# Altium schematic sheet 3_Sensing_V.SchDoc
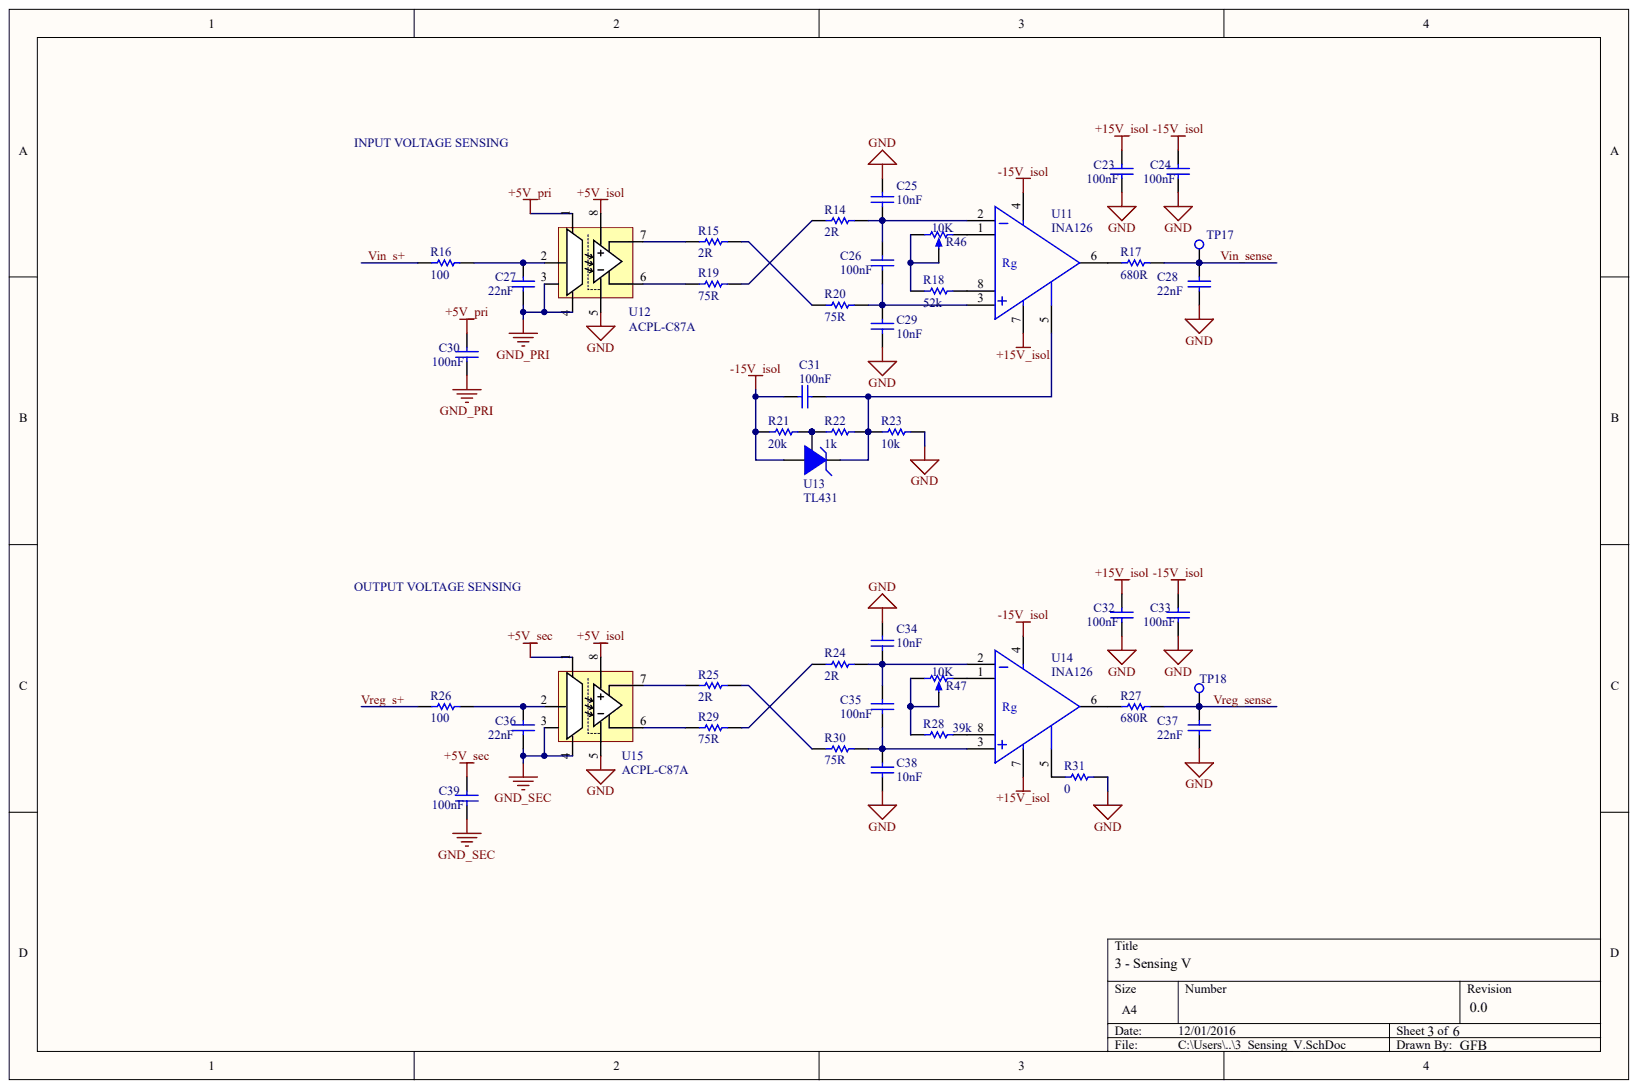
[diagram p0: 3_Sensing_V.SchDoc]

## Parts
C23  value 100nF  (hidden comment: Cap)
C24  value 100nF  (hidden comment: Cap)
C25  value 10nF  (hidden comment: Cap)
C26  value 100nF  (hidden comment: Cap)
C27  value 22nF  (hidden comment: Cap)
C28  value 22nF  (hidden comment: Cap)
C29  value 10nF  (hidden comment: Cap)
C30  value 100nF  (hidden comment: Cap)
C31  value 100nF  (hidden comment: Cap)
C32  value 100nF  (hidden comment: Cap)
C33  value 100nF  (hidden comment: Cap)
C34  value 10nF  (hidden comment: Cap)
C35  value 100nF  (hidden comment: Cap)
C36  value 22nF  (hidden comment: Cap)
C37  value 22nF  (hidden comment: Cap)
C38  value 10nF  (hidden comment: Cap)
C39  value 100nF  (hidden comment: Cap)
R14  value 2R  (hidden comment: Res1)
R15  value 2R  (hidden comment: Res1)
R16  value 100  (hidden comment: Res1)
R17  value 680R  (hidden comment: Res1)
R18  value 52k  (hidden comment: Res1)
R19  value 75R  (hidden comment: Res1)
R20  value 75R  (hidden comment: Res1)
R21  value 20k  (hidden comment: Res1)
R22  value 1k  (hidden comment: Res1)
R23  value 10k  (hidden comment: Res1)
R24  value 2R  (hidden comment: Res1)
R25  value 2R  (hidden comment: Res1)
R26  value 100  (hidden comment: Res1)
R27  value 680R  (hidden comment: Res1)
R28  value 39k  (hidden comment: Res1)
R29  value 75R  (hidden comment: Res1)
R30  value 75R  (hidden comment: Res1)
R31  value 0  (hidden comment: Res1)
R46  value 10K
R47  value 10K
TP17  TestPoint  (value not drawn)
TP18  TestPoint  (value not drawn)
U11  INA126
U12  ACPL-C87A
U13  TL431
U14  INA126
U15  ACPL-C87A

## Nets
+5V_ISOL: U12.8 U15.8
+5V_PRI: C30.2 U12.1
+5V_SEC: C39.2 U15.1
+15V_ISOL: C23.2 C32.2 U11.7 U14.7
-15V_ISOL: C24.2 C31.2 C33.2 R21.1 U11.4 U13.2 U14.4
GND: C23.1 C24.1 C25.2 C28.1 C29.1 C32.1 C33.1 C34.2 C37.1 C38.1 R23.2 R31.1 U12.5 U15.5
GND_PRI: C27.1 C30.1 U12.3 U12.4
GND_SEC: C36.1 C39.1 U15.3 U15.4
VIN_S+: R16.2
VIN_SENSE: C28.2 R17.1 TP17.1
VREG_S+: R26.2
VREG_SENSE: C37.2 R27.1 TP18.1
NetC25_1: C25.1 C26.2 R14.1 U11.2
NetC26_1: C26.1 C29.2 R20.1 U11.3
NetC27_2: C27.2 R16.1 U12.2
NetC31_1: C31.1 R22.2 R23.1 U11.5 U13.3
NetC34_1: C34.1 C35.2 R24.1 U14.2
NetC35_1: C35.1 C38.2 R30.1 U14.3
NetC36_2: C36.2 R26.1 U15.2
NetR14_2: R14.2 R19.1
NetR15_1: R15.1 R20.2
NetR15_2: R15.2 U12.7
NetR17_2: R17.2 U11.6
NetR18_1: R18.1 R46.1 R46.3
NetR18_2: R18.2 U11.8
NetR19_2: R19.2 U12.6
NetR21_2: R21.2 R22.1 U13.1
NetR24_2: R24.2 R29.1
NetR25_1: R25.1 R30.2
NetR25_2: R25.2 U15.7
NetR27_2: R27.2 U14.6
NetR28_1: R28.1 R47.1 R47.3
NetR28_2: R28.2 U14.8
NetR29_2: R29.2 U15.6
NetR31_2: R31.2 U14.5
NetR46_2: R46.2 U11.1
NetR47_2: R47.2 U14.1

## Library data of the parts
R14-R31 = (no symbol name): Power: 5W; Published: 8-Jun-2000; LatestRevisionDate: 17-Jul-2002; LatestRevisionNote: Re-released for DXP Platform.; PackageReference: AXIAL-0.3; PackageDescription: Axial Device, Thru-Hole; 2 Leads; 0.3 in Pin Spacing; Publisher: Altium Limited
  R14-R23: ClassName: Vin_sensing
  R24-R31: ClassName: Vout_sensing
R46 R47 = (no symbol name): Sim Note: Enter the Resistance value and the set point into parameter fields.; Set Position: 0.5; Published: 8-Jun-2000; LatestRevisionDate: 17-Jul-2002; LatestRevisionNote: Re-released for DXP Platform.; PackageReference: VR5; PackageDescription: Variable Resistor, Thru-Hole; 3 Leads; Publisher: Altium Limited
TP17 TP18 = (no symbol name): RS: 100-107
  TP17: ClassName: Vin_sensing
  TP18: ClassName: Vout_sensing
U11 U14 = (no symbol name): Manufacturer: Texas Instruments; Manufacturer Part Number: INA126EA/250; Amplifier Type: Instrumentation; Number of Circuits: 1; Slew Rate: 0.4 V/µs; -3db Bandwidth: 200kHz; Current - Input Bias: 10nA; Voltage - Input Offset: 150µV; Current - Supply: 175µA; Current - Output / Channel: 10mA; Voltage - Supply, Single/Dual (±): 2.7 V ~ 36 V, ±1.35 V ~ 18 V; Supplier 1: Digi-Key; RoHS: RoHS Compliant; Category: Integrated Circuits (ICs); Stock 1: 1250; Pricing 1: 250=2.14448, 500=1.92422 (EUR); Packaging: Tape & Reel (TR); Output Type: -; Gain Bandwidth Product: -; Operating Temperature: -40°C ~ 85°C; Mounting Type: Surface Mount; Package / Case: 8-TSSOP, 8-MSOP (0.118", 3.00mm Width); Supplier Device Package: 8-VSSOP; ComponentLink1URL: http://www.ti.com/lit/ds/symlink/ina126.pdf; ComponentLink1Description: http://www.ti.com/lit/ds/symlink/ina126.pdf; Supplier Part Number 1: INA126EATR-ND; HelpURL: C:\Users\Gonzalo\GoogleDrive\UC3M\Flyback_board\3_datasheets\opamp_ina126.pdf
  U11: ClassName: Vin_sensing
  U14: ClassName: Vout_sensing
C23-C39 U12 U13 U15 = (no symbol name)
  C23-C31: Published: 8-Jun-2000; LatestRevisionDate: 17-Jul-2002; LatestRevisionNote: Re-released for DXP Platform.; PackageReference: RAD-0.3; PackageDescription: Radial Cap, Thru-Hole; 2 Leads; 0.3 in Pin Spacing; ClassName: Vin_sensing
  C32-C39: Published: 8-Jun-2000; LatestRevisionDate: 17-Jul-2002; LatestRevisionNote: Re-released for DXP Platform.; PackageReference: RAD-0.3; PackageDescription: Radial Cap, Thru-Hole; 2 Leads; 0.3 in Pin Spacing; ClassName: Vout_sensing
  U12: ClassName: Vin_sensing
  U13: Published: 8-Jun-2000; LatestRevisionDate: 17-Jul-2002; LatestRevisionNote: Re-released for DXP Platform.; PackageReference: DIODE-0.7; PackageDescription: Diode, Thru-Hole; 2 Leads; 0.7 in Pin Spacing; ClassName: Vin_sensing
  U15: ClassName: Vout_sensing
  C23-C39 U13: Publisher: Altium Limited
